# Altium schematic sheet Power.SchDoc
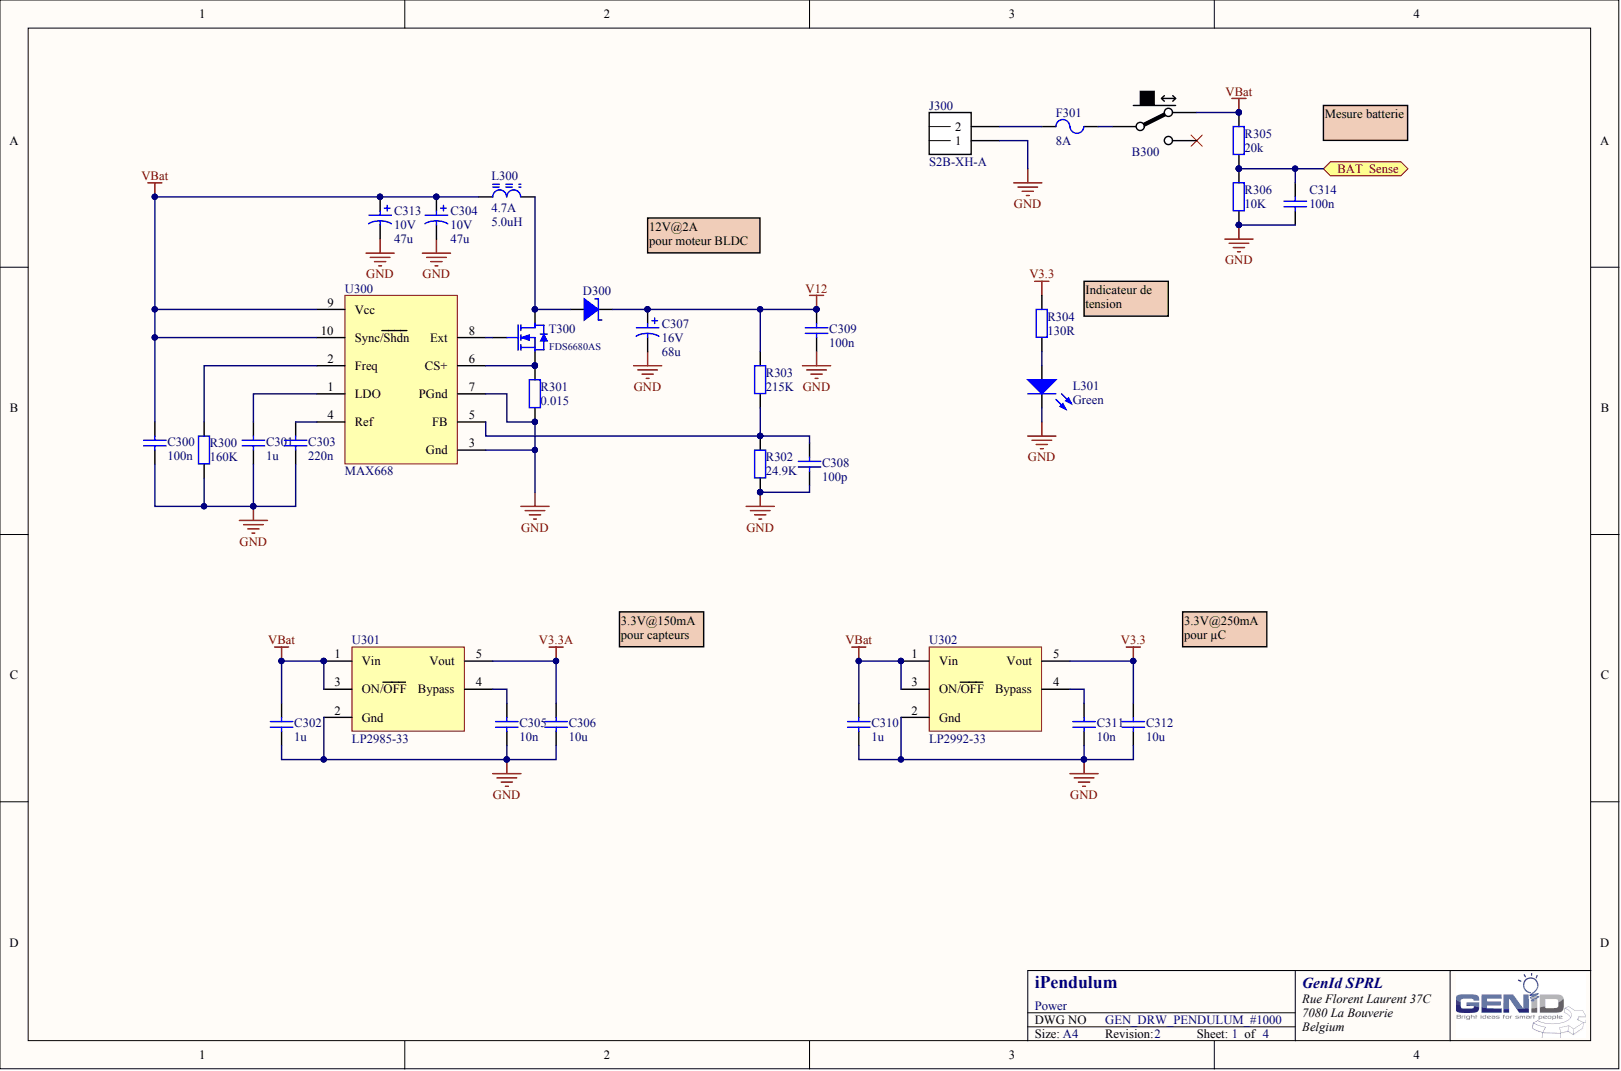
[diagram p0: Power.SchDoc]

## Parts
B300  1101M2S3ABE2  [C&K SPDT HORZ 90]  (value not drawn)
C300  value 100n  [0805-CAP]
C301  value 1u  [0805-CAP]
C302  value 1u  [0805-CAP]
C303  value 220n  [0805-CAP]
C304  value 47u  Voltage: 10V  [SMD KEMET B]
C305  value 10n  [0805-CAP]
C306  value 10u  [1206-CAP]
C307  value 68u  Voltage: 16V  [SMD KEMET D]
C308  value 100p  [0805-CAP]
C309  value 100n  [0805-CAP]
C310  value 1u  [0805-CAP]
C311  value 10n  [0805-CAP]
C312  value 10u  [1206-CAP]
C313  value 47u  Voltage: 10V  [SMD KEMET B]
C314  value 100n  [0805-CAP]
D300  (no value)  [SMC-403]
F301  I Hold: 8A  [SMF Omni-Blok]  (hidden comment: Surface Mount NANO2 Very Fast-Acting 448 Series)
J300  S2B-XH-A  [S2B-XH-A]
L300  value 5.0uH  Imax: 4.7A  [BOURNS-SRR1206]
L301  Color: Green  [1206-LED-GREEN]
R300  value 160K  [SMD 0805]
R301  value 0.015  [SMD 2512]
R302  value 24.9K  [SMD 0805]
R303  value 215K  [SMD 0805]
R304  value 130R  [SMD 0805]
R305  value 20k  [SMD 0805]
R306  value 10K  [SMD 0805]
T300  (no value)  [SOIC_8]
U300  (no value)  [µMAX]
U301  (no value)  [SOT-23]
U302  (no value)  [SOT-23]

## Ports
BAT_SENSE

## Nets
GND: C300.2 C301.2 C302.2 C303.2 C304.2 C305.2 C306.2 C307.2 C308.2 C309.2 C310.2 C311.2 C312.2 C313.2 C314.2 J300.1 L301.2 R300.1 R301.1 R302.1 R306.1 U300.3(Gnd) U300.7(PGnd) U301.2(Gnd) U302.2(Gnd)
V3.3: C312.1 R304.2 U302.5(Vout)
V3.3A: C306.1 U301.5(Vout)
V12: C307.1 C309.1 D300.2 R303.2
VBAT: B300.1 C300.1 C302.1 C304.1 C310.1 C313.1 L300.1 R305.2 U300.9(Vcc) U300.10(Sync/Shdn) U301.1(Vin) U301.3(ON/OFF) U302.1(Vin) U302.3(ON/OFF)
NetB300_2: B300.2 F301.2
NetC301_1: C301.1 U300.1(LDO)
NetC303_1: C303.1 U300.4(Ref)
NetC305_1: C305.1 U301.4(Bypass)
NetC308_1: C308.1 R302.2 R303.1 U300.5(FB)
NetC311_1: C311.1 U302.4(Bypass)
NetC314_1: C314.1 R305.1 R306.2 ; port BAT_SENSE
NetD300_1: D300.1 L300.2 T300.5
NetF301_1: F301.1 J300.2
NetL301_1: L301.1 R304.1
NetR300_2: R300.2 U300.2(Freq)
NetR301_2: R301.2 T300.1 U300.6(CS+)
NetT300_4: T300.4 U300.8(Ext)

Not connected: B300 3

## Library data of the parts
B300 = 1101M2S3ABE2: SPDT, RIGHT ANGLE, PC THRU-HOLE, Horizontal Actuation; Manufacturer: C&K; Imax: 6A; Vmax DC: 28V; Component Kind: Standard; HelpURL: http://www.ck-components.com/1000/slide,10529,en.html; Reference: 1101M2S3ABE2; Library Name: libActuators.IntLib
C300 = C0805C104K8RA: 100n-0805-10V-10%-X7R; Manufacturer: Kemet; Dielectric: X7R; Tolerance: 10%; Voltage: 10V; Component Kind: Standard; HelpURL: http://www.kemet.com/kemet/web/homepage/kechome.nsf/weben/DC9E4F3CDBEF8272852579290047C2CA/$file/KEM_CC101_COMM_SMD.pdf; Library Name: libCapacitors.IntLib; Reference: C0805C104K8RA
C301 C302 C310 = C0805C105K4RA: 1u-0805-16V-10%-X7R; Manufacturer: Kemet; Dielectric: X7R; Tolerance: 10%; Voltage: 16V; Component Kind: Standard; HelpURL: http://www.kemet.com/kemet/web/homepage/kechome.nsf/weben/DC9E4F3CDBEF8272852579290047C2CA/$file/KEM_CC101_COMM_SMD.pdf; Library Name: libCapacitors.IntLib; Reference: C0805C105K4RA
C303 = C0805C224K5RA: 220n-0805-50V-10%-X7R; Manufacturer: Kemet; Dielectric: X7R; Tolerance: 10%; Voltage: 50V; Component Kind: Standard; HelpURL: http://www.kemet.com/kemet/web/homepage/kechome.nsf/weben/DC9E4F3CDBEF8272852579290047C2CA/$file/KEM_CC101_COMM_SMD.pdf; Library Name: libCapacitors.IntLib; Reference: C0805C224K5RA
C304 C313 = T520B476M010ATE035: 47u-10V-20%-0.035R; Manufacturer: Kemet; ESR: 0.035; Max Ripple Current: 1.9A; Tolerance: 20%; Component Kind: Standard; HelpURL: http://www.kemet.com/Lists/ProductCatalog/Attachments/421/KEM_T2015_T520.pdf; Library Name: libCapacitors.IntLib; Reference: T520B476M010ATE035
C305 C311 = C0805C103K5RA: 10n-0805-50V-10%-X7R; Manufacturer: Kemet; Dielectric: X7R; Tolerance: 10%; Voltage: 50V; Component Kind: Standard; HelpURL: http://www.kemet.com/kemet/web/homepage/kechome.nsf/weben/DC9E4F3CDBEF8272852579290047C2CA/$file/KEM_CC101_COMM_SMD.pdf; Library Name: libCapacitors.IntLib; Reference: C0805C103K5RA
C306 C312 = C1206C106K4RA: 10u-1206-16V-10%-X7R; Manufacturer: Kemet; Dielectric: X7R; Tolerance: 10%; Voltage: 16V; Component Kind: Standard; HelpURL: http://www.kemet.com/kemet/web/homepage/kechome.nsf/weben/DC9E4F3CDBEF8272852579290047C2CA/$file/KEM_CC101_COMM_SMD.pdf; Library Name: libCapacitors.IntLib; Reference: C1206C106K4RA
C307 = T520D686M016ATE050: 68u-16V-20%-0.050R; Manufacturer: Kemet; ESR: 0.050; Max Ripple Current: 2.1A; Tolerance: 20%; Component Kind: Standard; HelpURL: http://www.kemet.com/Lists/ProductCatalog/Attachments/421/KEM_T2015_T520.pdf; Library Name: libCapacitors.IntLib; Reference: T520D686M016ATE050
C308 = C0805C101J5GA: 100p-0805-50V-5%-C0G; Manufacturer: Kemet; Dielectric: C0G; Tolerance: 5%; Voltage: 50V; Component Kind: Standard; HelpURL: http://www.kemet.com/kemet/web/homepage/kechome.nsf/weben/DC9E4F3CDBEF8272852579290047C2CA/$file/KEM_CC101_COMM_SMD.pdf; Library Name: libCapacitors.IntLib; Reference: C0805C101J5GA
C309 C314 = C0805C104K5RA: 100n-0805-50V-10%-X7R; Manufacturer: Kemet; Dielectric: X7R; Tolerance: 10%; Voltage: 50V; Component Kind: Standard; HelpURL: http://www.kemet.com/kemet/web/homepage/kechome.nsf/weben/DC9E4F3CDBEF8272852579290047C2CA/$file/KEM_CC101_COMM_SMD.pdf; Reference: C0805C104K5RA
  C309: Library Name: libCapacitors.IntLib
  C314: Library Name: libCapacitors
D300 = MBRS340T3: Surface Mount Schottky Power Rectifier; Manufacturer: ON SemiConductor; If: 3A; VR: 40V; Component Kind: Standard; HelpURL: http://www.onsemi.com/PowerSolutions/product.do?id=MBRS340; Library Name: libDiodes.IntLib; Reference: MBRS340T3
F301 = 0448 008.: 8A-125V; Manufacturer: Littelfuse; I2t: 18.33; R: 0.0078R; Vmax: 125V; Component Kind: Standard; HelpURL: http://www.littelfuse.com/~/media/Electronics/Datasheets/Fuses/Littelfuse_Fuse_448_Datasheet.pdf.pdf; Library Name: libFuses.IntLib; Reference: 0448 008.
J300 = S2B-XH-A: XH 2 ways Disconnectable Crimp style connectors; Manufacturer: JST; Current: 3A; Voltage: 250V; Component Kind: Standard; HelpURL: http://www.jst-mfg.com/product/detail_e.php?series=277; Library Name: libConnectors.IntLib; Reference: S2B-XH-A
L300 = SRR1206-5R0ML: Shielded High Power Inductor - 5.0uH; Manufacturer: Bourns; Rdc: 0.022ohm; SRF: 25MHz; Tolerance: 20%; Component Kind: Standard; HelpURL: http://www.bourns.com/data/global/pdfs/SRR1206.pdf; Library Name: libInductors.IntLib; Reference: SRR1206-5R0ML
L301 = KP-3216SGC: LED, SMD, 1206, GREEN; Manufacturer: Kingbright; If: 20mA; Vf: 2V; View angle: 120°; Component Kind: Standard; Library Name: libLED; Reference: KP-3216SGC
R300 = CRCW0805160KFKEA: 160K-0805-0.125W-1%-100ppm; Manufacturer: Vishay; Power: 1/8W; T. Coeff: 100ppm; Tolerance: 1%; Vmax: 150V; Component Kind: Standard; HelpURL: http://www.vishay.com/docs/20035/dcrcwe3.pdf; Library Name: libResistor.IntLib; Reference: CRCW0805160KFKEA
R301 = WSL2512R0150FEA: 15m-2512-1W-1%; Manufacturer: Vishay; Power: 1W; T. Coeff: 75ppm; Tolerance: 1%; Component Kind: Standard; HelpURL: http://www.vishay.com/docs/30100/wsl.pdf; Library Name: libResistor.IntLib; Reference: WSL2512R0150FEA
R302 = CRCW080524K9FKEA: 24.9K-0805-0.125W-1%-100ppm; Manufacturer: Vishay; Power: 1/8W; T. Coeff: 100ppm; Tolerance: 1%; Vmax: 150V; Component Kind: Standard; HelpURL: http://www.vishay.com/docs/20035/dcrcwe3.pdf; Library Name: libResistor.IntLib; Reference: CRCW080524K9FKEA
R303 = CRCW0805215KFKEA: 215K-0805-0.125W-1%-100ppm; Manufacturer: Vishay; Power: 1/8W; T. Coeff: 100ppm; Tolerance: 1%; Vmax: 150V; Component Kind: Standard; HelpURL: http://www.vishay.com/docs/20035/dcrcwe3.pdf; Library Name: libResistor.IntLib; Reference: CRCW0805215KFKEA
R304 = CRCW0805130RFKEA: 130R-0805-0.125W-1%-100ppm; Manufacturer: Vishay; Power: 1/8W; T. Coeff: 100ppm; Tolerance: 1%; Vmax: 150V; Component Kind: Standard; HelpURL: http://www.vishay.com/docs/20035/dcrcwe3.pdf; Library Name: libResistor.IntLib; Reference: CRCW0805130RFKEA
R305 = CRCW080520K0FKEA: 20K-0805-0.125W-1%-100ppm; Manufacturer: Vishay; Power: 1/8W; T. Coeff: 100ppm; Tolerance: 1%; Vmax: 150V; Component Kind: Standard; HelpURL: http://www.vishay.com/docs/20035/dcrcwe3.pdf; Library Name: libResistor.IntLib; Reference: CRCW080520K0FKEA
R306 = CRCW080510K0FKEA: 10K-0805-0.125W-1%-100ppm; Manufacturer: Vishay; Power: 1/8W; T. Coeff: 100ppm; Tolerance: 1%; Vmax: 150V; Component Kind: Standard; HelpURL: http://www.vishay.com/docs/20035/dcrcwe3.pdf; Library Name: libResistor.IntLib; Reference: CRCW080510K0FKEA
T300 = FDS6680AS: 30V N-Channel PowerTrench SyncFET; Manufacturer: Fairchild; Component Kind: Standard; HelpURL: https://www.fairchildsemi.com/ds/FD/FDS6680AS.pdf; Library Name: libTransistors.IntLib; Reference: FDS6680AS
U300 = MAX668: 1.8V to 28V Input, PWM Step-Up Controllers in µMAX; Manufacturer: Maxim Integrated; Component Kind: Standard; HelpURL: http://www.maximintegrated.com/en/products/power/switching-regulators/MAX668.html; Library Name: libIC-Power.IntLib; Reference: MAX668
U301 = LP2985-33: 150-mA Low-Noise Low-Dropout Regulator With Shutdown; Manufacturer: Texas Instruments; Component Kind: Standard; HelpURL: http://www.ti.com/lit/ds/symlink/lp2985-18.pdf; Library Name: libIC-Power.IntLib; Reference: LP2985-33
U302 = LP2992-33: Micropower 250mA Low-Noise Ultra Low-Dropout  Regulator; Manufacturer: Texas Instruments; Component Kind: Standard; HelpURL: http://www.ti.com/lit/ds/symlink/lp2992.pdf; Library Name: libIC-Power.IntLib; Reference: LP2992-33
